# Altium schematic sheet STEP MOTOR.SchDoc
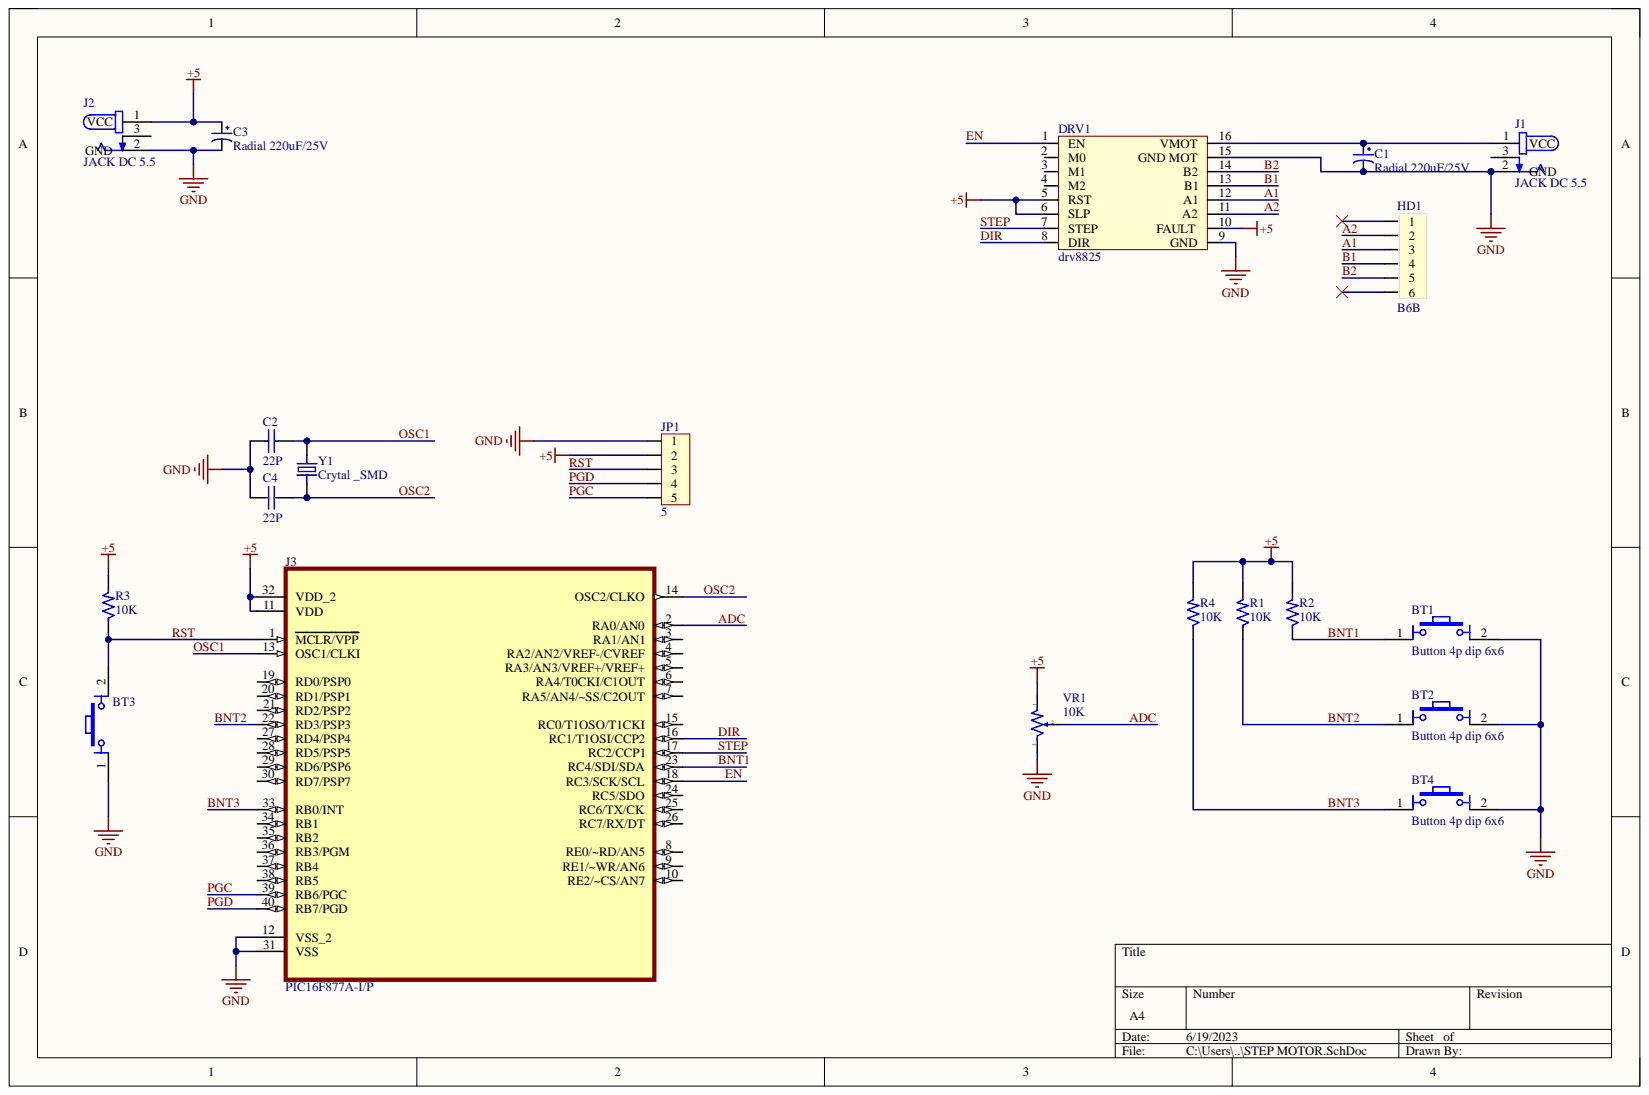
[diagram p0: STEP MOTOR.SchDoc]

## Parts
BT1  Button 4p dip 6x6  [Button 4p 6x6]
BT2  Button 4p dip 6x6  [Button 4p 6x6]
BT3  Button 4p dip 6x6  [Button 4p 6x6]
BT4  Button 4p dip 6x6  [Button 4p 6x6]
C1  Radial 220uF/25V  [Radial 6.3x12mm]
C2  22P  [Cap Ceramic 0805]
C3  Radial 220uF/25V  [Radial 6.3x12mm]
C4  22P  [Cap Ceramic 0805]
DRV1  drv8825  [drv8825]
HD1  B6B  [Header 6P]
J1  JACK DC 5.5  [JACK DC]
J2  JACK DC 5.5  [JACK DC]
J3  PIC16F877A-I/P  [DIP254P1524X483-40]
JP1  5  [JUMP5_T_MALE]
R1  10K  [Res_0805]
R2  10K  [Res_0805]
R3  10K  [Res_0805]
R4  10K  [Res_0805]
VR1  10K  [Bien Tro Volume Don]
Y1  Crytal _SMD  [Crytal_DIP]

## Nets
+5: C3.1 DRV1.5(RST) DRV1.6(SLP) DRV1.10(FAULT) J2.1 J3.11(VDD) J3.32(VDD_2) JP1.2 R1.2 R2.2 R3.2 R4.2 VR1.1
GND: BT1.2 BT2.2 BT3.1 BT4.2 C1.2 C2.1 C3.2 C4.1 DRV1.9(GND) DRV1.15(GND_MOT) J1.2 J2.2 J3.12(VSS_2) J3.31(VSS) JP1.1 VR1.3
A1: DRV1.12(A1) HD1.3
A2: DRV1.11(A2) HD1.2
ADC: J3.2(RA0/AN0) VR1.2
B1: DRV1.13(B1) HD1.4
B2: DRV1.14(B2) HD1.5
BNT1: BT1.1 J3.23(RC4/SDI/SDA) R2.1
BNT2: BT2.1 J3.22(RD3/PSP3) R1.1
BNT3: BT4.1 J3.33(RB0/INT) R4.1
DIR: DRV1.8(DIR) J3.16(RC1/T1OSI/CCP2)
EN: DRV1.1(EN) J3.18(RC3/SCK/SCL)
OSC1: C2.2 J3.13(OSC1/CLKI) Y1.2
OSC2: C4.2 J3.14(OSC2/CLKO) Y1.1
PGC: J3.39(RB6/PGC) JP1.5
PGD: J3.40(RB7/PGD) JP1.4
RST: BT3.2 J3.1(MCLR/VPP) JP1.3 R3.1
STEP: DRV1.7(STEP) J3.17(RC2/CCP1)
NetC1_1: C1.1 DRV1.16(VMOT) J1.1

Not connected: DRV1 2(M0) 3(M1) 4(M2); HD1 1 6; J1 3; J2 3; J3 3(RA1/AN1) 4(RA2/AN2/VREF-/CVREF) 5(RA3/AN3/VREF+/VREF+) 6(RA4/T0CKI/C1OUT) 7(RA5/AN4/~SS/C2OUT) 8(RE0/~RD/AN5) 9(RE1/~WR/AN6) 10(RE2/~CS/AN7) 15(RC0/T1OSO/T1CKI) 19(RD0/PSP0) 20(RD1/PSP1) 21(RD2/PSP2) 24(RC5/SDO) 25(RC6/TX/CK) 26(RC7/RX/DT) 27(RD4/PSP4) 28(RD5/PSP5) 29(RD6/PSP6) 30(RD7/PSP7) 34(RB1) 35(RB2) 36(RB3/PGM) 37(RB4) 38(RB5)

## Library data of the parts
BT1-BT4 = Button 4p dip 6x6: Nut Nhan 4 Chan 6x6mm; Ma_Hang: MH004584; Ten: Nút Nhấn 4 Chân 6x6x5MM DIP; Component Kind: Standard; Library Name: KS Chuong.SchLib
C2 C4 = CAP Ceramic SMD: Component Kind: Standard; Library Name: KS Chuong.SchLib
HD1 = Header 6P: Value: Connector 6; Component Kind: Standard; Description: Header 6P; Library Name: KS Chuong.SchLib
J1 J2 = JACK DC 5.5: 2,0 x 6,5 mm, 2,5 A, Yatay, A莽谋k Delik, Dc G眉莽 Jak谋 Konnekt枚r眉; MF: CUI Devices; MP: PJ-002A; Availability: Unavailable; Component Kind: Standard; CUI_purchase_URL: https://www.cuidevices.com/product/interconnect/connectors/dc-power-connectors/jacks/pj-002a?utm_source=snapeda.com&utm_medium=referral&utm_campaign=snapedaBOM; Package: None; Price: None; SnapEDA_Link: https://www.snapeda.com/parts/PJ-002A/CUI%20Devices/view-part/287588/?ref=snap; Library Name: KS Chuong.SchLib
J3 = PIC16F877A-I/P: PIC PICÂ® 16F Microcontroller IC 8-Bit 20MHz 14KB (8K x 14) FLASH 44-TQFP (10x10); MF: Microchip; Availability: In Stock; Check_prices: https://www.snapeda.com/parts/PIC16F877A-I/P/Microchip/view-part/49368/?ref=eda; Component Kind: Standard; MP: PIC16F877A-I/P; Package: DIP-40 Atmel; Price: None; SnapEDA_Link: https://www.snapeda.com/parts/PIC16F877A-I/P/Microchip/view-part/49368/?ref=snap; Library Name: KS Chuong.SchLib
JP1 = JUMP 1x5: JUMP5x1; Value: Connector 4; Component Kind: Standard; Library Name: KS Chuong.SchLib
R1-R4 = Res SMD: Component Kind: Standard; Library Name: KS Chuong.SchLib
VR1 = Potentiometer: Cắm; Don_Vi: Con; Ma_Hang: MH001417; Ten_Linh_Kien: Triết Áp Đơn B10K; Chung_Loai: Cắm; Component Kind: Standard; Library Name: KS Chuong.SchLib
Y1 = Crytal: 20MHz  Crystal Series 30 Ohms HC-49/US; MF: ECS Inc.; MP: ECS-200-S-5PX-TR; Availability: Unavailable; Component Kind: Standard; Package: HC49/US ECS International; Price: None; SnapEDA_Link: https://www.snapeda.com/parts/ECS-200-S-5PX-TR/ECS%20Inc./view-part/2459090/?ref=snap; Library Name: KS Chuong.SchLib
C1 C3 = Radial 220uF/25V: Component Kind: Standard; Description: Radial 220uF/25V; Library Name: KS Chuong.SchLib
DRV1 = drv8825: Component Kind: Standard; Library Name: Chuong Engineer.SchLib
